# Altium schematic sheet Power.SchDoc(Power)
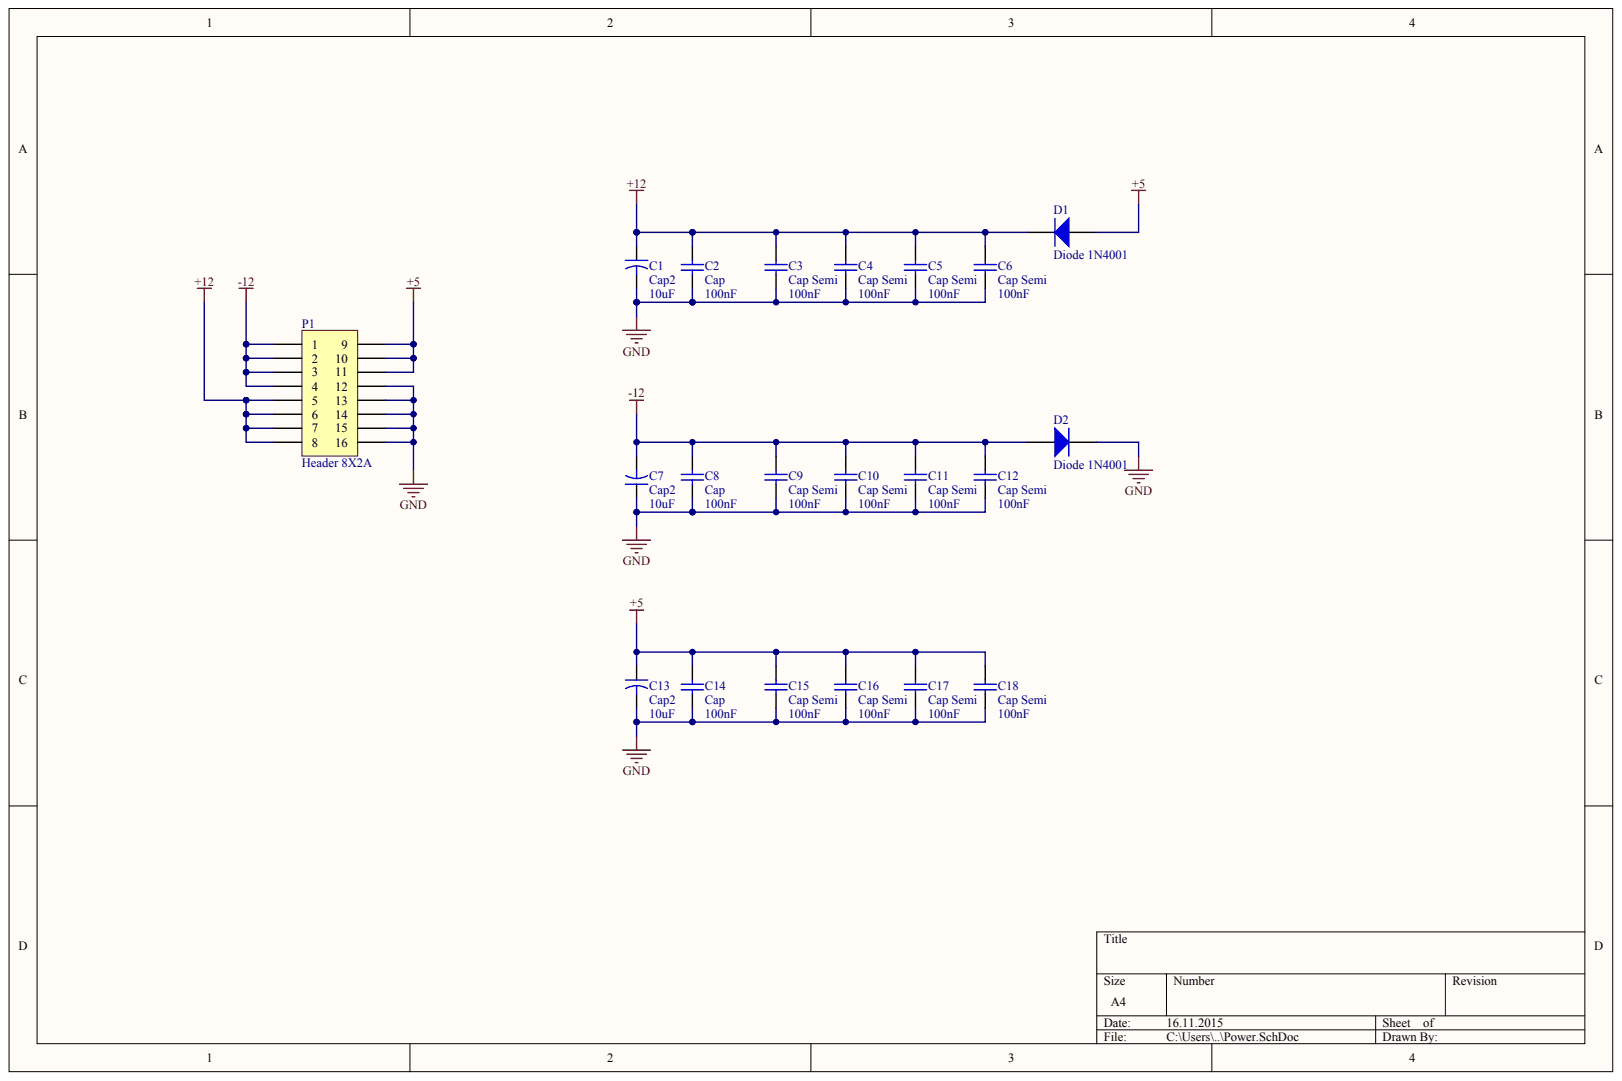
[diagram p0: Power.SchDoc]

## Parts
C1  Cap2  value 10uF
C2  Cap  value 100nF
C3  Cap Semi  value 100nF
C4  Cap Semi  value 100nF
C5  Cap Semi  value 100nF
C6  Cap Semi  value 100nF
C7  Cap2  value 10uF
C8  Cap  value 100nF
C9  Cap Semi  value 100nF
C10  Cap Semi  value 100nF
C11  Cap Semi  value 100nF
C12  Cap Semi  value 100nF
C13  Cap2  value 10uF
C14  Cap  value 100nF
C15  Cap Semi  value 100nF
C16  Cap Semi  value 100nF
C17  Cap Semi  value 100nF
C18  Cap Semi  value 100nF
D1  Diode 1N4001
D2  Diode 1N4001
P1  Header 8X2A

## Nets
+5: C13.1 C14.2 C15.1 C16.1 C17.1 C18.1 D1.1 P1.9 P1.10 P1.11
+12: C1.1 C2.2 C3.1 C4.1 C5.1 C6.1 D1.2 P1.5 P1.6 P1.7 P1.8
-12: C7.2 C8.2 C9.1 C10.1 C11.1 C12.1 D2.1 P1.1 P1.2 P1.3 P1.4
GND: C1.2 C2.1 C3.2 C4.2 C5.2 C6.2 C7.1 C8.1 C9.2 C10.2 C11.2 C12.2 C13.2 C14.1 C15.2 C16.2 C17.2 C18.2 D2.2 P1.12 P1.13 P1.14 P1.15 P1.16

## Library data of the parts
C1-C18 D1 D2 P1 = (no symbol name): Publisher: Altium Limited
  C1 C7 C13: Published: 23-Sep-2002; PackageReference: CAPR5-4X5; PackageDescription: Capacitor; 2 Leads
  C2 C8 C14: Published: 8-Jun-2000; LatestRevisionDate: 17-Jul-2002; LatestRevisionNote: Re-released for DXP Platform.; PackageReference: RAD-0.3; PackageDescription: Radial Cap, Thru-Hole; 2 Leads; 0.3 in Pin Spacing
  C3-C6 C9-C12 C15-C18: Published: 8-Jun-2000; LatestRevisionDate: 29-May-2009; LatestRevisionNote: IPC-7351 Footprint Added.; PackageReference: C1206; PackageDescription: Chip Capacitor
  D1 D2: Published: 8-Jun-2000; LatestRevisionDate: 17-Jul-2002; LatestRevisionNote: Re-released for DXP Platform.; DatasheetVersion: 1998; PackageVersion: Aug-1999; PackageReference: DO-41; PackageDescription: Diode, Axial; Body 5.2 x 2.7 mm (LxDia. max), Lead Dia. 0.86 mm (max); Code_JEDEC: DO-204-AL; ComponentLink1Description: Manufacturer Link; ComponentLink1URL: http://www.fairchildsemi.com/; ComponentLink2Description: Datasheet; ComponentLink2URL: http://www.fairchildsemi.com/ds/1N%2F1N4001.pdf
  P1: LatestRevisionDate: 17-Jul-2002; LatestRevisionNote: Re-released for DXP Platform.
